# Altium schematic sheet Schematic.SchDoc
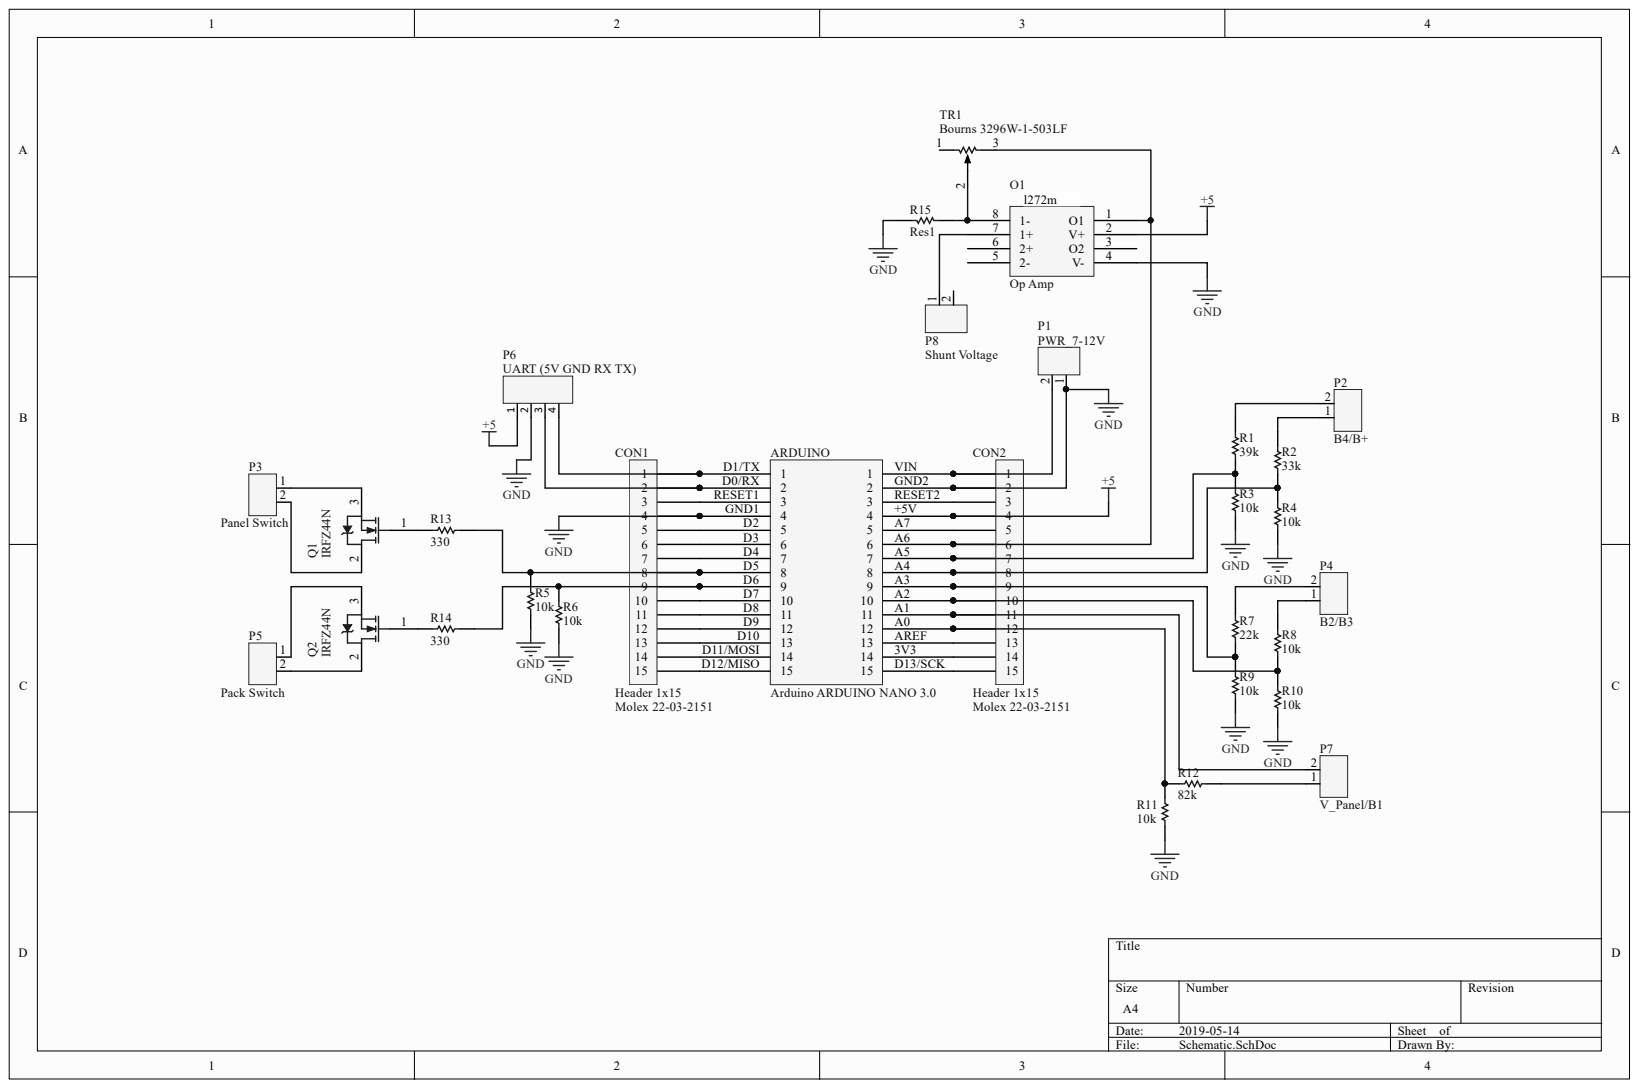
[diagram p0: Schematic.SchDoc]

## Parts
ARDUINO  Arduino ARDUINO NANO 3.0  [PCBComponent_1]
CON1  Molex 22-03-2151  [PCB-4tb8nx1bm3fy4wunglrs-1]
CON2  Molex 22-03-2151  [PCB-4tb8nx1bm3fy4wunglrs-1]
O1  Op Amp  [PCB-vl07or3mtd1cfc3ttymu-1]
P1  PWR_7-12V  [PCB-6xrngco598u3mra2uzi2-1]
P2  B4/B+  [PCB-6xrngco598u3mra2uzi2-1]
P3  Panel Switch  [PCB-6xrngco598u3mra2uzi2-1]
P4  B2/B3  [PCB-6xrngco598u3mra2uzi2-1]
P5  Pack Switch  [PCB-6xrngco598u3mra2uzi2-1]
P6  UART (5V GND RX TX)  [PCBComponent_1 - duplicate]
P7  V_Panel/B1  [PCB-6xrngco598u3mra2uzi2-1]
P8  Shunt Voltage  [PCB-6xrngco598u3mra2uzi2-1]
Q1  IRFZ44N  [PCB-18787308-1]
Q2  IRFZ44N  [PCB-18787308-1]
R1  39k  [R0603-nominal]
R2  33k  [RESC1609X50X30ML10T20]
R3  10k  [PCB-ojnm16i5yp9tvw7iv4rl-1]
R4  10k  [PCB-ojnm16i5yp9tvw7iv4rl-1]
R5  10k  [PCB-ojnm16i5yp9tvw7iv4rl-1]
R6  10k  [PCB-ojnm16i5yp9tvw7iv4rl-1]
R7  22k  [RESC1608X06M]
R8  10k  [PCB-ojnm16i5yp9tvw7iv4rl-1]
R9  10k  [PCB-ojnm16i5yp9tvw7iv4rl-1]
R10  10k  [PCB-ojnm16i5yp9tvw7iv4rl-1]
R11  10k  [PCB-ojnm16i5yp9tvw7iv4rl-1]
R12  82k  [0603R_A]
R13  330  [0603-RES]
R14  330  [0603-RES]
R15  Res1  [PCB-t6ekw5j97c0433t8n4ev-1]
TR1  Bourns 3296W-1-503LF  [PCB-l67f2lsv3qiirb5b93po-1]

## Nets
+5: ARDUINO.+5V CON2.4 O1.2(V+) P6.1
GND: ARDUINO.GND1 ARDUINO.GND2 CON1.4 CON2.2 O1.4(V-) P1.1 P6.2 R3.1 R4.1 R5.1 R6.1 R9.1 R10.1 R11.1 R15.2
NetARDUINO_3V3: ARDUINO.3V3 CON2.14
NetARDUINO_A0: ARDUINO.A0 CON2.12 R11.2 R12.1
NetARDUINO_A1: ARDUINO.A1 CON2.11 P7.2
NetARDUINO_A2: ARDUINO.A2 CON2.10 R8.1 R10.2
NetARDUINO_A3: ARDUINO.A3 CON2.9 R7.2 R9.2
NetARDUINO_A4: ARDUINO.A4 CON2.8 R2.1 R4.2
NetARDUINO_A5: ARDUINO.A5 CON2.7 R1.1 R3.2
NetARDUINO_A6: ARDUINO.A6 CON2.6 O1.1 TR1.3
NetARDUINO_A7: ARDUINO.A7 CON2.5
NetARDUINO_AREF: ARDUINO.AREF CON2.13
NetARDUINO_D0/RX: ARDUINO.D0/RX CON1.2 P6.3
NetARDUINO_D1/TX: ARDUINO.D1/TX CON1.1 P6.4
NetARDUINO_D2: ARDUINO.D2 CON1.5
NetARDUINO_D3: ARDUINO.D3 CON1.6
NetARDUINO_D4: ARDUINO.D4 CON1.7
NetARDUINO_D5: ARDUINO.D5 CON1.8 R5.2 R13.1
NetARDUINO_D6: ARDUINO.D6 CON1.9 R6.2 R14.1
NetARDUINO_D7: ARDUINO.D7 CON1.10
NetARDUINO_D8: ARDUINO.D8 CON1.11
NetARDUINO_D9: ARDUINO.D9 CON1.12
NetARDUINO_D10: ARDUINO.D10 CON1.13
NetARDUINO_D11/MOSI: ARDUINO.D11/MOSI CON1.14
NetARDUINO_D12/MISO: ARDUINO.D12/MISO CON1.15
NetARDUINO_D13/SCK: ARDUINO.D13/SCK CON2.15
NetARDUINO_RESET1: ARDUINO.RESET1 CON1.3
NetARDUINO_RESET2: ARDUINO.RESET2 CON2.3
NetARDUINO_VIN: ARDUINO.VIN CON2.1 P1.2
NetO1_7: O1.7(1+) P8.1
NetO1_8: O1.8(1-) R15.1 TR1.2
NetP2_1: P2.1 R2.2
NetP2_2: P2.2 R1.2
NetP3_1: P3.1 Q1.3
NetP3_2: P3.2 Q1.2
NetP4_1: P4.1 R8.2
NetP4_2: P4.2 R7.1
NetP5_1: P5.1 Q2.3
NetP5_2: P5.2 Q2.2
NetP7_1: P7.1 R12.2
NetQ1_1: Q1.1 R13.2
NetQ2_1: Q2.1 R14.2

Not connected: O1 3(O2) 5(2-) 6(2+); P8 2; TR1 1

## Library data of the parts
ARDUINO = CMP-1f01d29ed047c0c6-3: Arduino Nano 3.0; Lead-Free Status: Lead Free; Component Kind: Standard; Library Name: CommunityVault; OctopartID: 1f01d29ed047c0c6; Octopart URL: https://octopart.com/arduino+nano+3.0-arduino-76933664; RoHS: Compliant
CON1 CON2 = CMP-ab506251400c7497-5: KK® 254 Wire-to-Board Header, Single Row, Vertical, 15 Circuits, PA Polyamide Nylon, Tin (Sn) Plating; Component Kind: Standard; Library Name: CommunityVault
O1 = L272M: L272 Series 350 kHz 1 V/us Dual Power Operational Amplifier Through Hole - DIP-8; Bandwidth: 350 kHz; Gain: 75.0 dB; Lead-Free Status: Lead Free; Number of Channels: 2; Slew Rate: 1.00 V/μs; Supply Voltage (DC): 40.0 V (max); Case/Package: DIP; Component Kind: Standard; Gain Bandwidth Product: 350 kHz; Library Name: Octopart library; Lifecycle Status: Active; Manufacturer Part Number: L272M; Mounting Style: Through Hole; Number of Circuits: 2; Number of Pins: 8; OctopartID: bf5576933cbf6092; Octopart URL: http://octopart.com/l272m-fairchild+semiconductor-13411980; Packaging: Tube; REACH SVHC Compliance: No SVHC; RoHS: Compliant
P1-P5 P7 P8 = 282834-2: Wire-To-Board Terminal Block, 2, 150 V, 10 A, 30 Awg, 16 Awg, 1.4 Mm; ELV Compliant: Compliant; Lead-Free Status: Lead Free; Number of Contacts: 2; Wire Gauge: 16.0 AWG to 30.0 AWG; Color: Green; Component Kind: Standard; Contact Material: Brass; Contact Plating: Tin; Flammability Rating: UL 94 V-0; Library Name: Octopart library; Mounting Style: Screw, Through Hole; Octopart URL: https://octopart.com/282834-2-te+connectivity-46506870; RoHS: Compliant; Size-Width: 6.50 mm
P6 = 282834-4: Conn; Term Blk; PCB; Wire Receptacle; 4; 2.54mm; 30-16AWG; Green; 10A; 150V; M1.6; Component Kind: Standard; Library Name: Octopart library; Manufacturer Part Number: 282834-4
Q1 Q2 = IRFZ44NPBF: N-Fet to220; Breakdown Voltage (Drain to Source): 55.0 V; Breakdown Voltage [Gate to Source]: -20.0 V to 20.0 V; Continuous Drain Current (Ids): 49.0 A; Drain to Source Resistance (on) (Rds): 17.5 mΩ; Gate Charge: 63.0 nC; Lead-Free Status: Lead Free; Polarity: N-Channel; Power Dissipation: 83.0 W; Rise Time: 60.0 ns; Component Kind: Standard; Current Rating: 49.0 A; Drain to Source Voltage (Vds): 55.0 V; Library Name: Octopart library; Lifecycle Status: Active; Manufacturer Part Number: IRFZ44NPBF; Number of Pins: 3; OctopartID: 444b8d311b939b8c; Octopart URL: http://octopart.com/irfz44npbf-international+rectifier-7371454; Packaging: Bulk; Part Family: IRFZ44N; REACH SVHC Compliance: No SVHC; RoHS: Compliant; Voltage Rating (DC): 55.0 V
R1 = CRCW060339K0FKEA: RES SMD 39K OHM 1% 1/10W 0603; Power Rating: 0.1 W; Resistance: 39000.0 Ω; Voltage Rating (DC): 75.0 V; Case/Package: 0603; Component Kind: Standard; Library Name: Octopart library; Manufacturer Part Number: CRCW060339K0FKEA; OctopartID: 98cc53990e02470b; Octopart Url: https://octopart.com/crcw060339k0fkea-vishay-39826344; Resistance Tolerance: ±1%; RoHS: Compliant; Size-Height: 0.00045 m; Size-Length: 0.00155 m; Size-Width: 0.00085 m
R2 = CRCW060333K0FKEA: RES SMD 33K OHM 1% 1/10W 0603; Lead-Free Status: Lead Free; Power Rating: 0.1 W; Resistance: 33000.0 Ω; Voltage Rating (DC): 75.0 V; Case/Package: 0603; Component Kind: Standard; Library Name: Octopart library; Manufacturer Part Number: CRCW060333K0FKEA; Mounting Style: Surface Mount; Number of Pins: 2; OctopartID: 5ed1958477568116; Octopart Url: https://octopart.com/crcw060333k0fkea-vishay-39783649; REACH SVHC Compliance: No SVHC; Resistance Tolerance: ±1%; RoHS: Compliant; Size-Height: 0.00045 m; Size-Length: 0.00155 m; Size-Width: 0.00085 m
R3-R6 R8-R11 = 1614881-1: Res Thin Film 0603 10K Ohm 0.1% 1/16W ±25ppm/°C Molded SMD SMD Paper T/R; Manufacturer Part Number: CPF0603B10KE; Lead-Free Status: Lead Free; Power Rating: 63.0 mW; Resistance: 10.0 kΩ; Case/Package: 0603; Component Kind: Standard; Library Name: Octopart library; Lifecycle Status: Active; Mounting Style: Surface Mount; Octopart URL: https://octopart.com/cpf0603b10ke-te+connectivity-22735560; Packaging: Tape & Reel (TR); RoHS: Compliant
R7 = ERJ-3EKF2202V: Precision resistor, SMD [Panasonic Automotive & Industrial Systems] ERJ3EKF2202V Precision resistor, SMD; Case/Package (SI): 1608; Power Rating: 100 mW; Resistance: 22.0 kΩ; Resistance Tolerance: ±1%; Voltage Rating (DC): 75.0 V; Case/Package: 0603; Component Kind: Standard; Library Name: Octopart library; Lifecycle Status: Not Listed by Manufacturer; Mounting Style: Surface Mount; Number of Pins: 2; OctopartID: 96265fefacce49e1; Octopart URL: http://octopart.com/erj-3ekf2202v-panasonic-12787598; Packaging: Tape & Reel (TR), Cut Tape (CT); REACH SVHC Compliance: No SVHC; RoHS: Compliant
R12 = ERJ-3EKF8202V: Precision resistor, SMD [Panasonic Automotive & Industrial Systems] ERJ3EKF8202V Precision resistor, SMD; Lead-Free Status: Lead Free; Power Rating: 100 mW; Resistance: 82.0 kΩ; Resistance Tolerance: ±1%; Voltage Rating (DC): 75.0 V; Case/Package: 0603; Component Kind: Standard; Library Name: Octopart library; Lifecycle Status: Not Listed by Manufacturer; Manufacturer Part Number: ERJ-3EKF8202V; Mounting Style: Surface Mount; Number of Pins: 2; OctopartID: d0c6194010730f01; Octopart Url: http://octopart.com/erj-3ekf8202v-panasonic-9233875; Packaging: Tape & Reel (TR), Cut Tape (CT); REACH SVHC Compliance: No SVHC; RoHS: Compliant; Seller Landing  Url: https://octopart-clicks.com/click/track?country=US&ak=8157f166&sig=0cd40b1&sid=1106&ppid=9233875&vpid=116763972&ct=offers
R13 R14 = ERJP03F3300V: PANASONIC   ERJP03F3300V   RESISTOR, THICK FILM, 330 OHM, 0.2W, 1%; Manufacturer Part Number: ERJ-P03F3300V; Resistance: 330.0 Ω; Voltage Rating (DC): 150.0 V; Component Kind: Standard; Library Name: Octopart library; Lifecycle Status: Not Listed by Manufacturer; OctopartID: a4b26c32a8567b75; Octopart Url: https://octopart.com/erj-p03f3300v-panasonic-25831810; Packaging: Cut Tape (CT); Power Rating: 0.2 W; Resistance Tolerance: ±1%; RoHS: Compliant
R15 = ERJ-3GEYJ121V: Res Thick Film 0603 120 Ohm 5% 0.1W(1/10W) ???}200ppm/??? Molded SMD Automotive Punched T/R; Case/Package (SI): 1608; Lead-Free Status: Lead Free; Temperature Coefficient: -200 ppm/°C to 200 ppm/°C; Component Kind: Standard; Library Name: Octopart library; Manufacturer Part Number: ERJ-3GEYJ121V; Mounting Style: Surface Mount; OctopartID: 38e1f2a6b198e726; Resistance Tolerance: ±5%; Size-Height: 450 µm; Size-Length: 1.60 mm
TR1 = 3296W-1-503LF: Trimmer; Cermet; Rest 50 Kilohms; PCB; Pwr-Rtg 0.5W; Dim 0.375InLx0.19InWx0.395InH; Resistance: 50.0 kΩ; Temperature Coefficient: -100 ppm/°C to 100 ppm/°C; Component Kind: Standard; Library Name: Octopart library; Size-Length: 9.52 mm; Size-Width: 4.83 mm; Weight: 851 mg
